# Altium schematic sheet PCard.SchDoc
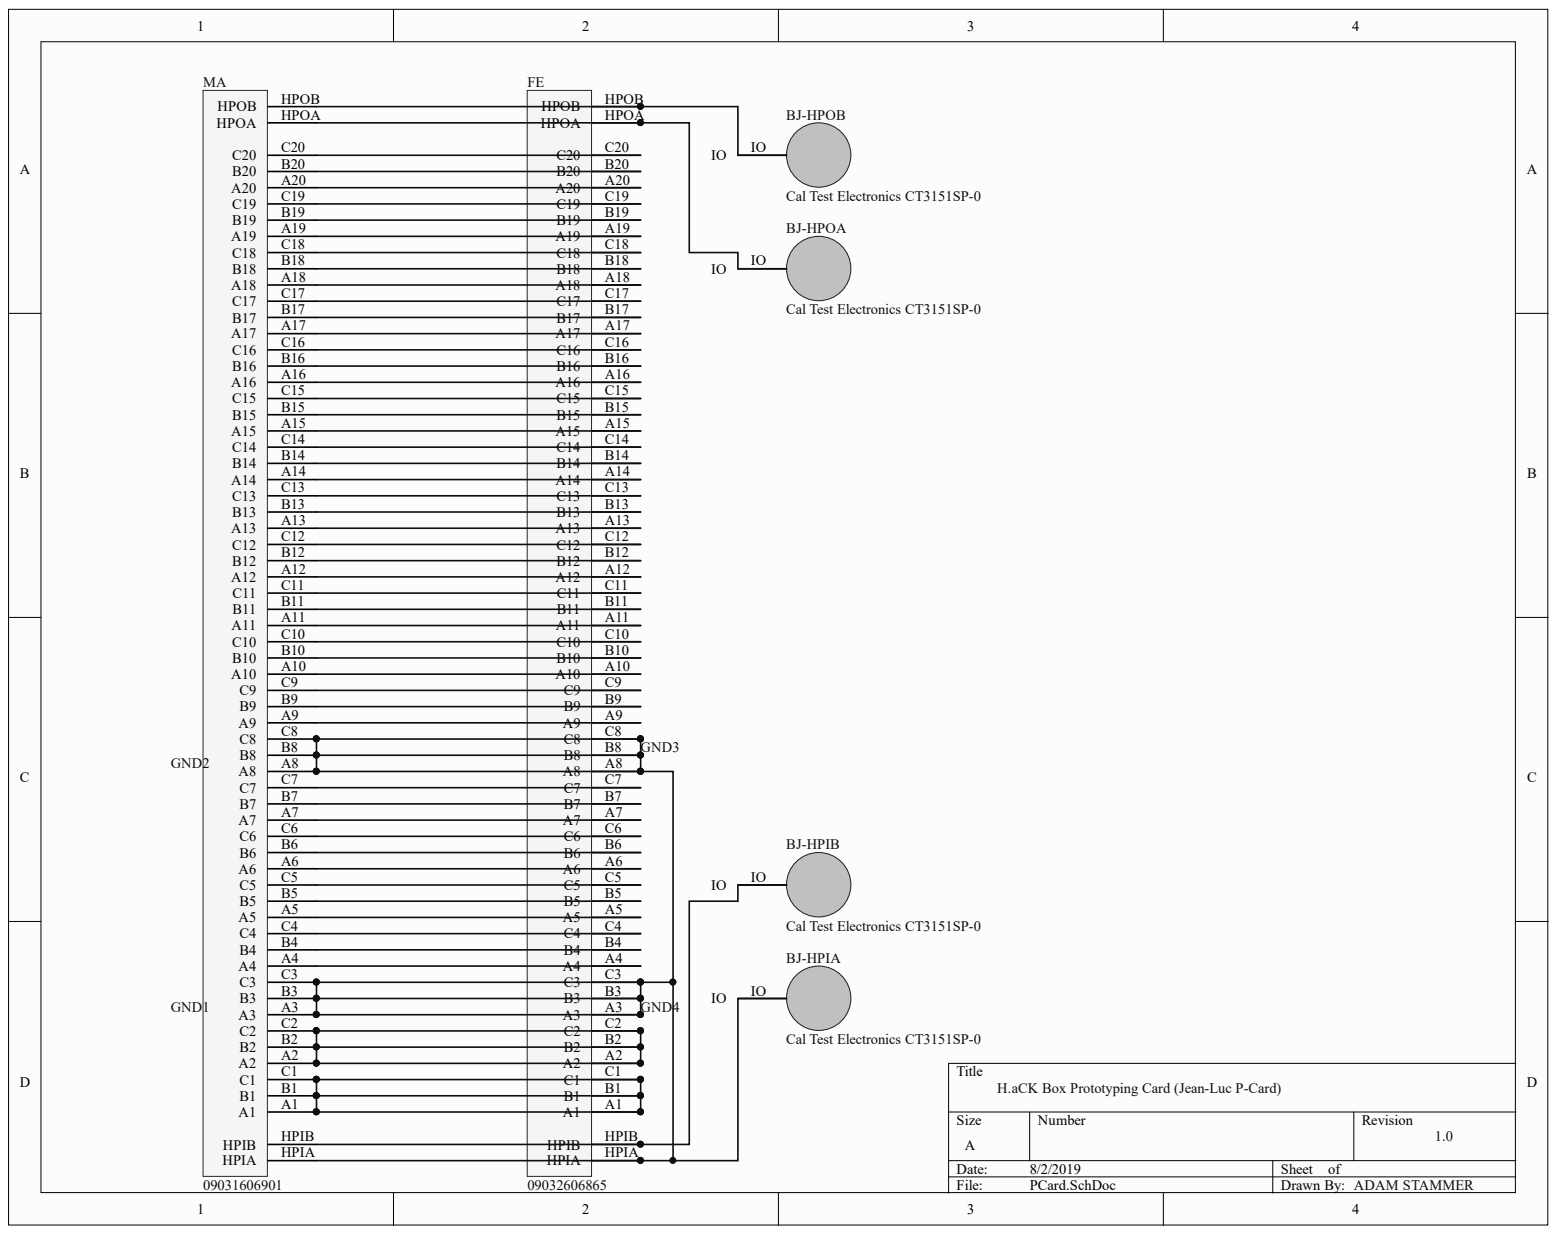
[diagram p0: PCard.SchDoc]

## Parts
BJ-HPIA  Cal Test Electronics CT3151SP-0  [PCB-w5qi9w8z5aaxrmdn6hpp-1]
BJ-HPIB  Cal Test Electronics CT3151SP-0  [PCB-w5qi9w8z5aaxrmdn6hpp-1]
BJ-HPOA  Cal Test Electronics CT3151SP-0  [PCB-w5qi9w8z5aaxrmdn6hpp-1]
BJ-HPOB  Cal Test Electronics CT3151SP-0  [PCB-w5qi9w8z5aaxrmdn6hpp-1]
FE  09032606865  [PCBComponent_1 - duplicate]
MA  09031606901  [PCB-uzhe8vs3bcqz6bzs18qy-1]

## Nets
NetBJ-HPIA_IO: BJ-HPIA.IO FE.A3 FE.A8 FE.B3 FE.B8 FE.C3 FE.C8 FE.HPIA MA.A3 MA.A8 MA.B3 MA.B8 MA.C3 MA.C8 MA.HPIA
NetBJ-HPIB_IO: BJ-HPIB.IO FE.HPIB MA.HPIB
NetBJ-HPOA_IO: BJ-HPOA.IO FE.HPOA MA.HPOA
NetBJ-HPOB_IO: BJ-HPOB.IO FE.HPOB MA.HPOB
NetFE_A1: FE.A1 FE.B1 FE.C1 MA.A1 MA.B1 MA.C1
NetFE_A2: FE.A2 FE.B2 FE.C2 MA.A2 MA.B2 MA.C2
NetFE_A4: FE.A4 MA.A4
NetFE_A5: FE.A5 MA.A5
NetFE_A6: FE.A6 MA.A6
NetFE_A7: FE.A7 MA.A7
NetFE_A9: FE.A9 MA.A9
NetFE_A10: FE.A10 MA.A10
NetFE_A11: FE.A11 MA.A11
NetFE_A12: FE.A12 MA.A12
NetFE_A13: FE.A13 MA.A13
NetFE_A14: FE.A14 MA.A14
NetFE_A15: FE.A15 MA.A15
NetFE_A16: FE.A16 MA.A16
NetFE_A17: FE.A17 MA.A17
NetFE_A18: FE.A18 MA.A18
NetFE_A19: FE.A19 MA.A19
NetFE_A20: FE.A20 MA.A20
NetFE_B4: FE.B4 MA.B4
NetFE_B5: FE.B5 MA.B5
NetFE_B6: FE.B6 MA.B6
NetFE_B7: FE.B7 MA.B7
NetFE_B9: FE.B9 MA.B9
NetFE_B10: FE.B10 MA.B10
NetFE_B11: FE.B11 MA.B11
NetFE_B12: FE.B12 MA.B12
NetFE_B13: FE.B13 MA.B13
NetFE_B14: FE.B14 MA.B14
NetFE_B15: FE.B15 MA.B15
NetFE_B16: FE.B16 MA.B16
NetFE_B17: FE.B17 MA.B17
NetFE_B18: FE.B18 MA.B18
NetFE_B19: FE.B19 MA.B19
NetFE_B20: FE.B20 MA.B20
NetFE_C4: FE.C4 MA.C4
NetFE_C5: FE.C5 MA.C5
NetFE_C6: FE.C6 MA.C6
NetFE_C7: FE.C7 MA.C7
NetFE_C9: FE.C9 MA.C9
NetFE_C10: FE.C10 MA.C10
NetFE_C11: FE.C11 MA.C11
NetFE_C12: FE.C12 MA.C12
NetFE_C13: FE.C13 MA.C13
NetFE_C14: FE.C14 MA.C14
NetFE_C15: FE.C15 MA.C15
NetFE_C16: FE.C16 MA.C16
NetFE_C17: FE.C17 MA.C17
NetFE_C18: FE.C18 MA.C18
NetFE_C19: FE.C19 MA.C19
NetFE_C20: FE.C20 MA.C20

## Library data of the parts
BJ-HPIA BJ-HPIB BJ-HPOA BJ-HPOB = CMP-869a4c9259de595d-1: 4MM Safety Jack , Horizontal Pcb Mount Black; Component Kind: Standard; Digikey Part Number: BKCT3151SP-0-ND; Library Name: CommunityVault; Manufacturer Part Number: CT3151SP-0; OctopartID: 869a4c9259de595d; Octopart URL: https://octopart.com/ct3151sp-0-cal+test+electronics-50526754
FE = CMP-dgd04bf3j3drhl5uyyz7-11: CONN DIN RCPT 64POS PCB GOLD; Component Kind: Standard; CustomComponentID: dgd04bf3j3drhl5uyyz7; Library Name: CommunityVault
MA = CMP-dd70146577e902b7-5: Conn DIN 41612 M 60Power/4Coaxial POS 2.54mm Solder RA Thru-Hole; Number of Mating Cycles: 400; Component Kind: Standard; Contact Plating: Gold over Nickel, Gold; Library Name: CommunityVault; Manufacturer Part Number: 09031606901; Mounting Style: Through Hole, PC Board, Solder; Octopart URL: https://octopart.com/09031606901-harting+elektronik-2068479; Packaging: Box; RoHS: Compliant
